# Altium schematic sheet Alimentation.SchDoc(Alimentation)
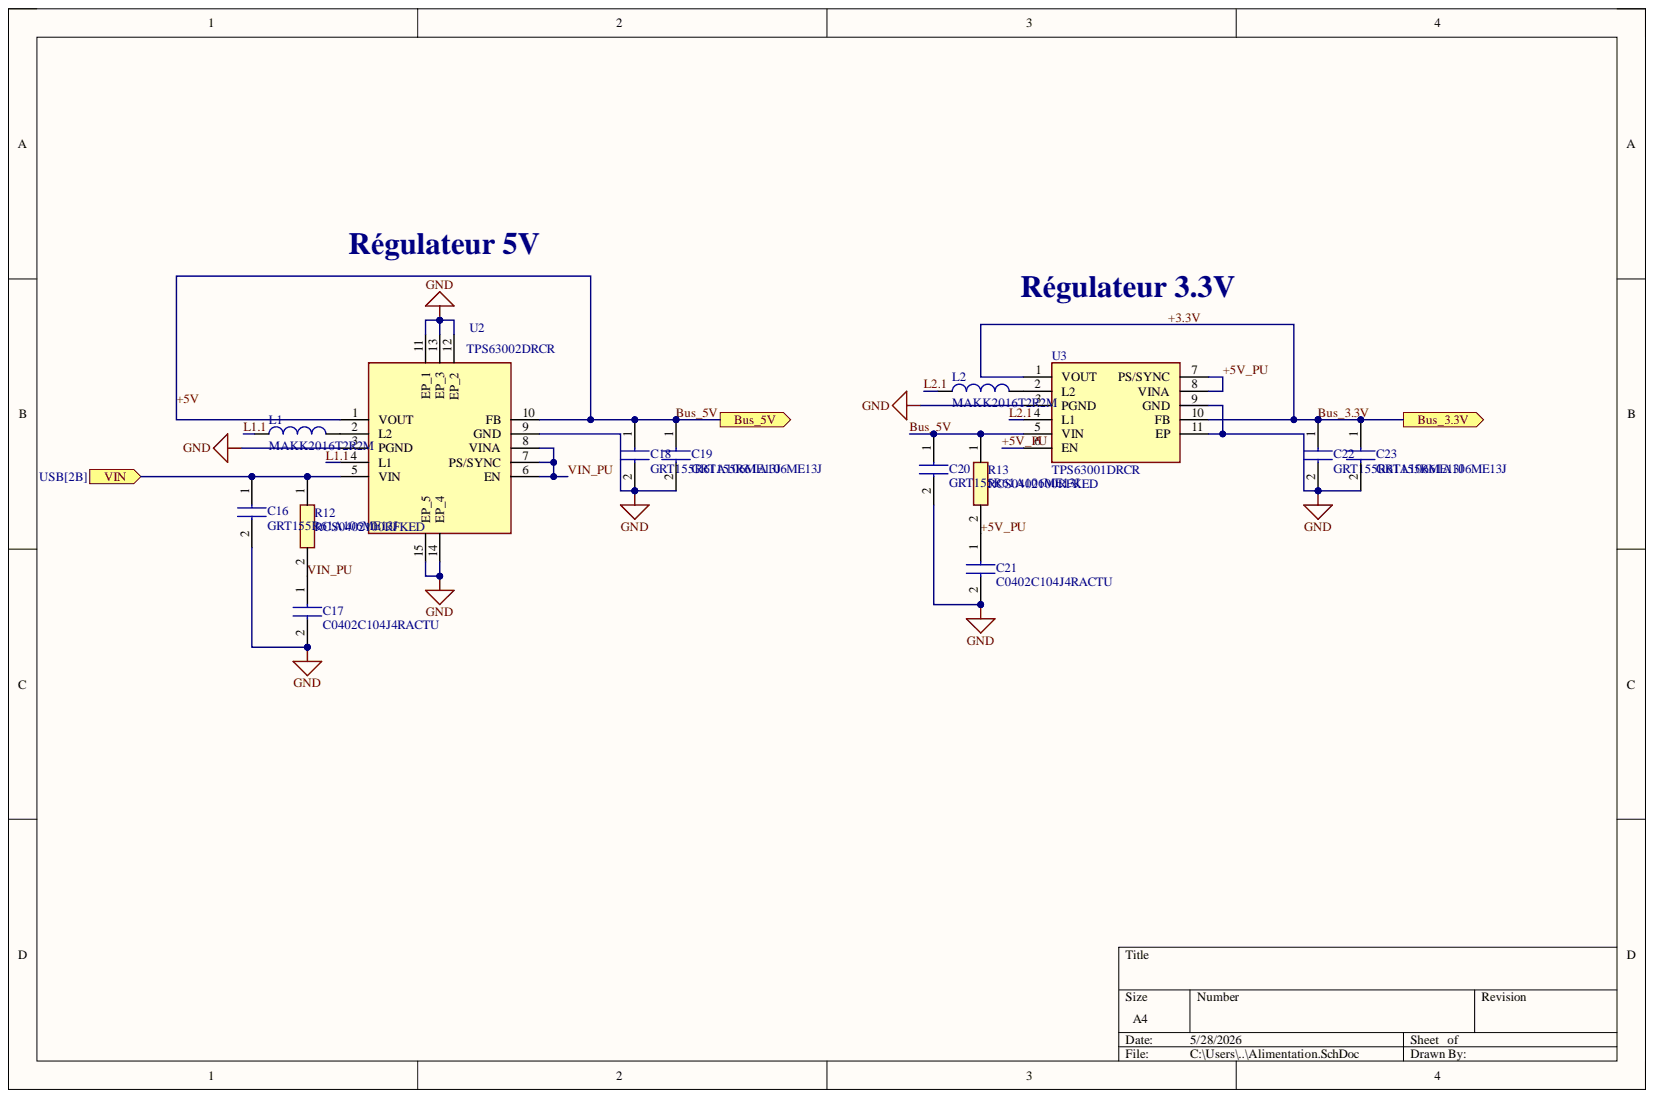
[diagram p0: Alimentation.SchDoc]

## Parts
C16  GRT155R61A106ME13J  [CAPC1005X70N]
C17  C0402C104J4RACTU  [C0402C104J4RACTU]
C18  GRT155R61A106ME13J  [CAPC1005X70N]
C19  GRT155R61A106ME13J  [CAPC1005X70N]
C20  GRT155R61A106ME13J  [CAPC1005X70N]
C21  C0402C104J4RACTU  [C0402C104J4RACTU]
C22  GRT155R61A106ME13J  [CAPC1005X70N]
C23  GRT155R61A106ME13J  [CAPC1005X70N]
L1  MAKK2016T2R2M  [INDC2016X100N]
L2  MAKK2016T2R2M  [INDC2016X100N]
R12  RCS0402100RFKED  [RCS0402_E3]
R13  RCS0402100RFKED  [RCS0402_E3]
U2  TPS63002DRCR  [TPS63002DRCR]
U3  TPS63001DRCR  [TPS74901DRCR]

## Ports
Bus_3.3V, Bus_5V, VIN

## Nets
GND: C16.2 C17.2 C18.2 C19.2 C20.2 C21.2 C22.2 C23.2 U2.3(PGND) U2.9(GND) U2.11(EP_1) U2.12(EP_2) U2.13(EP_3) U2.14(EP_4) U2.15(EP_5) U3.3(PGND) U3.9(GND) U3.11(EP)
+3.3V: C22.1 C23.1 U3.1(VOUT) U3.10(FB) ; port Bus_3.3V
+5V: C18.1 C19.1 C20.1 R13.1 U2.1(VOUT) U2.10(FB) U3.5(VIN) ; port Bus_5V
+5V_PU: C21.1 R13.2 U3.6(EN) U3.7(PS/SYNC) U3.8(VINA)
L1.1: L1.1 U2.4(L1)
L2.1: L2.1 U3.4(L1)
VIN: C16.1 R12.1 U2.5(VIN) ; port VIN
VIN_PU: C17.1 R12.2 U2.6(EN) U2.7(PS/SYNC) U2.8(VINA)
NetL1_2: L1.2 U2.2(L2)
NetL2_2: L2.2 U3.2(L2)

## Library data of the parts
C16 C18-C20 C22 C23 = GRM155R61A475MEAAD: Capacitor; Manufacturer_Name: Murata Electronics; Arrow Part Number: GRM155R61A475MEAAD; Arrow Price/Stock: https://www.arrow.com/en/products/grm155r61a475meaad/murata-manufacturing?utm_currency=USD&region=nac; Component Kind: Standard; Datasheet Link: https://search.murata.co.jp/Ceramy/image/img/A01X/G101/ENG/GRM155R61A475MEAA-01A.pdf; Height: 0.7mm; Manufacturer_Part_Number: GRM155R61A475MEAAD; Mouser Part Number: 81-GRM155R61A475MEAD; Mouser Price/Stock: https://www.mouser.co.uk/ProductDetail/Murata-Electronics/GRM155R61A475MEAAD?qs=AEetWsPH0IuXrVCc8yqzOA%3D%3D; Library Name: ADSC_V2.IntLib
C17 C21 = C0402C104J4RACTU: Condensateur 100nF; Manufacturer_Name: KEMET; Arrow Part Number: C0402C104J4RACTU; Arrow Price/Stock: https://www.arrow.com/en/products/c0402c104j4ractu/kemet-corporation?utm_currency=USD&region=nac; Component Kind: Standard; Datasheet Link: https://content.kemet.com/datasheets/KEM_C1002_X7R_SMD.pdf; Height: 0.55mm; Manufacturer_Part_Number: C0402C104J4RACTU; Mouser Part Number: 80-C0402C104J4R; Mouser Price/Stock: https://www.mouser.co.uk/ProductDetail/KEMET/C0402C104J4RACTU?qs=hOC58ESJ5%2FTi6zdFDTVLPg%3D%3D; Library Name: ADSC_V2.IntLib
L1 L2 = MAKK2016T2R2M: MF: {'manufacturer': u'Taiyo Yuden'}; Availability: Unavailable; Component Kind: Standard; Library Name: MAKK2016T2R2M.IntLib; MP: MAKK2016T2R2M; Package: None; Price: None
R12 R13 = RCS0402100RFKED: Resistor; Manufacturer_Name: Vishay; Arrow Part Number: RCS0402100RFKED; Arrow Price/Stock: https://www.arrow.com/en/products/rcs0402100rfked/vishay?utm_currency=USD&region=nac; Component Kind: Standard; Datasheet Link: https://www.vishay.com/docs/20065/rcse3.pdf; Manufacturer_Part_Number: RCS0402100RFKED; Mouser Part Number: 71-RCS0402100RFKED; Mouser Price/Stock: https://www.mouser.co.uk/ProductDetail/Vishay-Dale/RCS0402100RFKED?qs=NKmfXavxMaykuHEaJBK0%252BA%3D%3D; Library Name: ADSC_V2.IntLib
U2 = TPS63002DRCR: Integrated Circuit; Manufacturer_Name: Texas Instruments; Arrow Part Number: TPS63002DRCR; Arrow Price/Stock: https://www.arrow.com/en/products/tps63002drcr/texas-instruments?region=nac; Component Kind: Standard; Datasheet Link: https://www.ti.com/lit/gpn/TPS63002; Height: 1mm; Manufacturer_Part_Number: TPS63002DRCR; Mouser Part Number: 595-TPS63002DRCR; Mouser Price/Stock: https://www.mouser.co.uk/ProductDetail/Texas-Instruments/TPS63002DRCR?qs=QZuYiDxzHagvx6A2KHyUrA%3D%3D; Library Name: ADSC_V2.IntLib
U3 = TPS63001DRCR: Integrated Circuit; Manufacturer_Name: Texas Instruments; Arrow Part Number: TPS63001DRCR; Arrow Price/Stock: https://www.arrow.com/en/products/tps63001drcr/texas-instruments?utm_currency=USD&region=nac; Component Kind: Standard; Datasheet Link: https://www.ti.com/lit/ds/symlink/tps63001.pdf?ts=1616520267195&ref_url=https%253A%252F%252Fwww.ti.com%252Fstore%252Fti%252Fen%252Fp%252Fproduct%252F%253Fp%253DTPS63001DRCT; Manufacturer_Part_Number: TPS63001DRCR; Mouser Part Number: 595-TPS63001DRCR; Mouser Price/Stock: https://www.mouser.co.uk/ProductDetail/Texas-Instruments/TPS63001DRCR?qs=QZuYiDxzHagn01UZf%2F%252B1Bw%3D%3D; Library Name: ADSC_V2.IntLib
